# Altium schematic sheet [11]-POWER_BACKUP.SchDoc([11]-POWER_BACKUP)
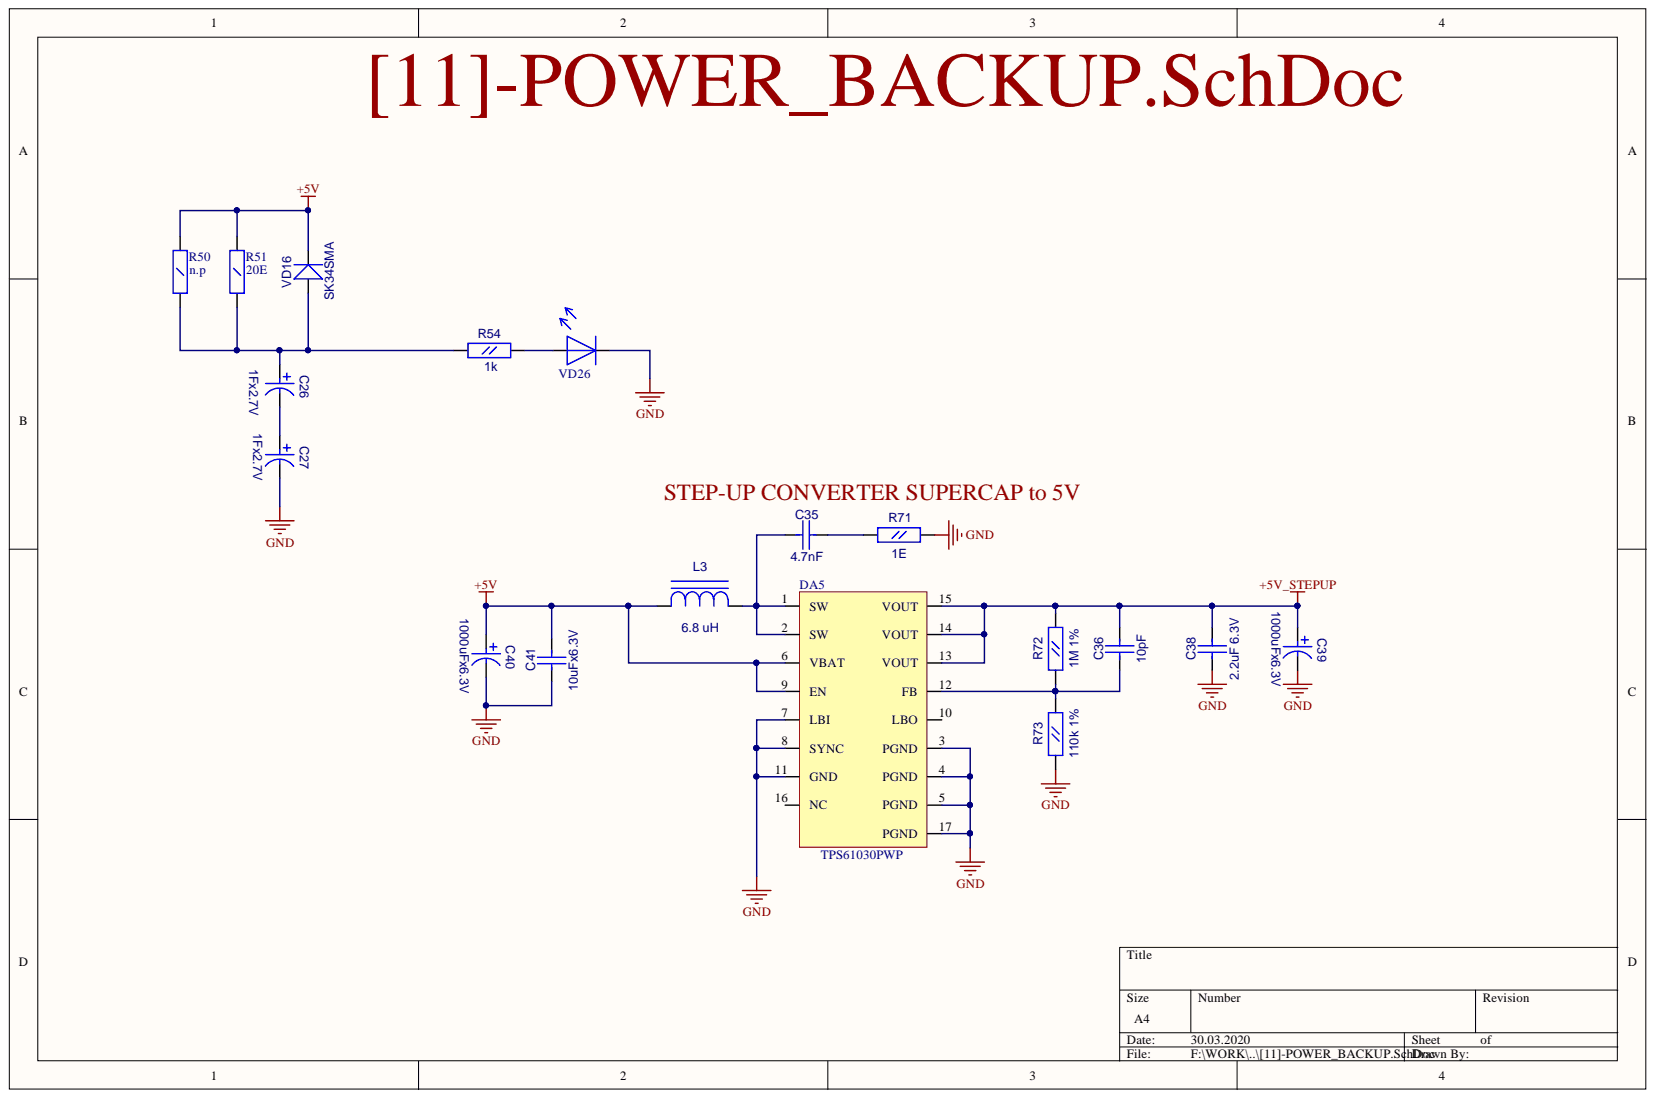
[diagram p0: [11]-POWER_BACKUP.SchDoc]

## Parts
C26  1Fx2.7V  [CC8X11.5_L]
C27  1Fx2.7V  [CC8X11.5_L]
C35  4.7nF  [CC0603]
C36  10pF  [CC0603]
C38  2.2uF 6.3V  [CC0805]
C39  value 1000uFx6.3V  [CC8X3.5]  (hidden comment: CAP0.35)
C40  value 1000uFx6.3V  [CC8X3.5]  (hidden comment: CAP0.35)
C41  10uFx6.3V  [CC0805]
DA5  TPS61030PWP  [R-PDSO-G16]
L3  6.8 uH  [SDR0805]
R50  n.p  [R2512]
R51  20E  [R2512]
R54  1k  [RC0603]
R71  1E  [RC0603]
R72  1M 1%  [RC0603]
R73  110k 1%  [RC0603]
VD16  SK34SMA  [SMA]
VD26  LED_0805  [LED0805]  (value not drawn)

## Nets
+5V: C40.1 C41.2 DA5.6(VBAT) DA5.9(EN) L3.1 R50.2 R51.2 VD16.2
+5V_STEPUP: C36.2 C38.2 C39.1 DA5.13(VOUT) DA5.14(VOUT) DA5.15(VOUT) R72.2
GND: C27.2 C38.1 C39.2 C40.2 C41.1 DA5.3(PGND) DA5.4(PGND) DA5.5(PGND) DA5.7(LBI) DA5.8(SYNC) DA5.11(GND) DA5.17(PGND) R71.2 R73.1 VD26.2
NetC26_1: C26.1 R50.1 R51.1 R54.1 VD16.1
NetC26_2: C26.2 C27.1
NetC35_1: C35.1 DA5.1(SW) DA5.2(SW) L3.2
NetC35_2: C35.2 R71.1
NetC36_1: C36.1 DA5.12(FB) R72.1 R73.2
NetR54_2: R54.2 VD26.1

Not connected: DA5 10(LBO) 16(NC)

## Library data of the parts
C26 C27 = CAP_8mm: Radial capacitor; Value: 1Fx2.7V; Component Kind: Standard; Library Name: fizik_lib.SchLib
C35 C36 = CC0603: Description: CC0603; Component Kind: Standard; Library Name: gprs_modem_2.SchLib
  C35: Value: 4.7nF
  C36: Value: 10pF
C38 C41 = CC0805: Description: CC0805; Component Kind: Standard; Library Name: gprs_modem_2.SchLib
  C38: Value: 2.2uF 6.3V
  C41: Value: 10uFx6.3V
DA5 = TPS61030PWP: Boost converter; Component Kind: Standard; Library Name: gprs_modem.SchLib
L3 = SDR0805: Value: 6.8 uH; Description: SDR0805; Component Kind: Standard; Library Name: gprs_modem_2.SchLib
R50 R51 = RC2512: Resistor 2512 1W; Component Kind: Standard; Library Name: fizik_lib.SchLib
  R50: Value: n.p
  R51: Value: 20E
R54 R71-R73 = RC0603: Description: RC0603; Component Kind: Standard
  R54: Value: 1k; Library Name: fizik_lib.SchLib
  R71: Value: 1E
  R72: Value: 1M 1%
  R73: Value: 110k 1%
  R71-R73: Library Name: gprs_modem_2.SchLib
VD16 = SK34SMA: Schottky Rectifier; Value: {Value}; Component Kind: Standard; Library Name: gprs_modem.SchLib
C39 C40 = CAP0.35: Description: CAP0.35; Component Kind: Standard; Library Name: gprs_modem_2.SchLib
VD26 = LED_0805: Value: LED_0805; Component Kind: Standard; Library Name: fizik_lib.SchLib
